# Altium schematic sheet Э3("All Documents",Physical)
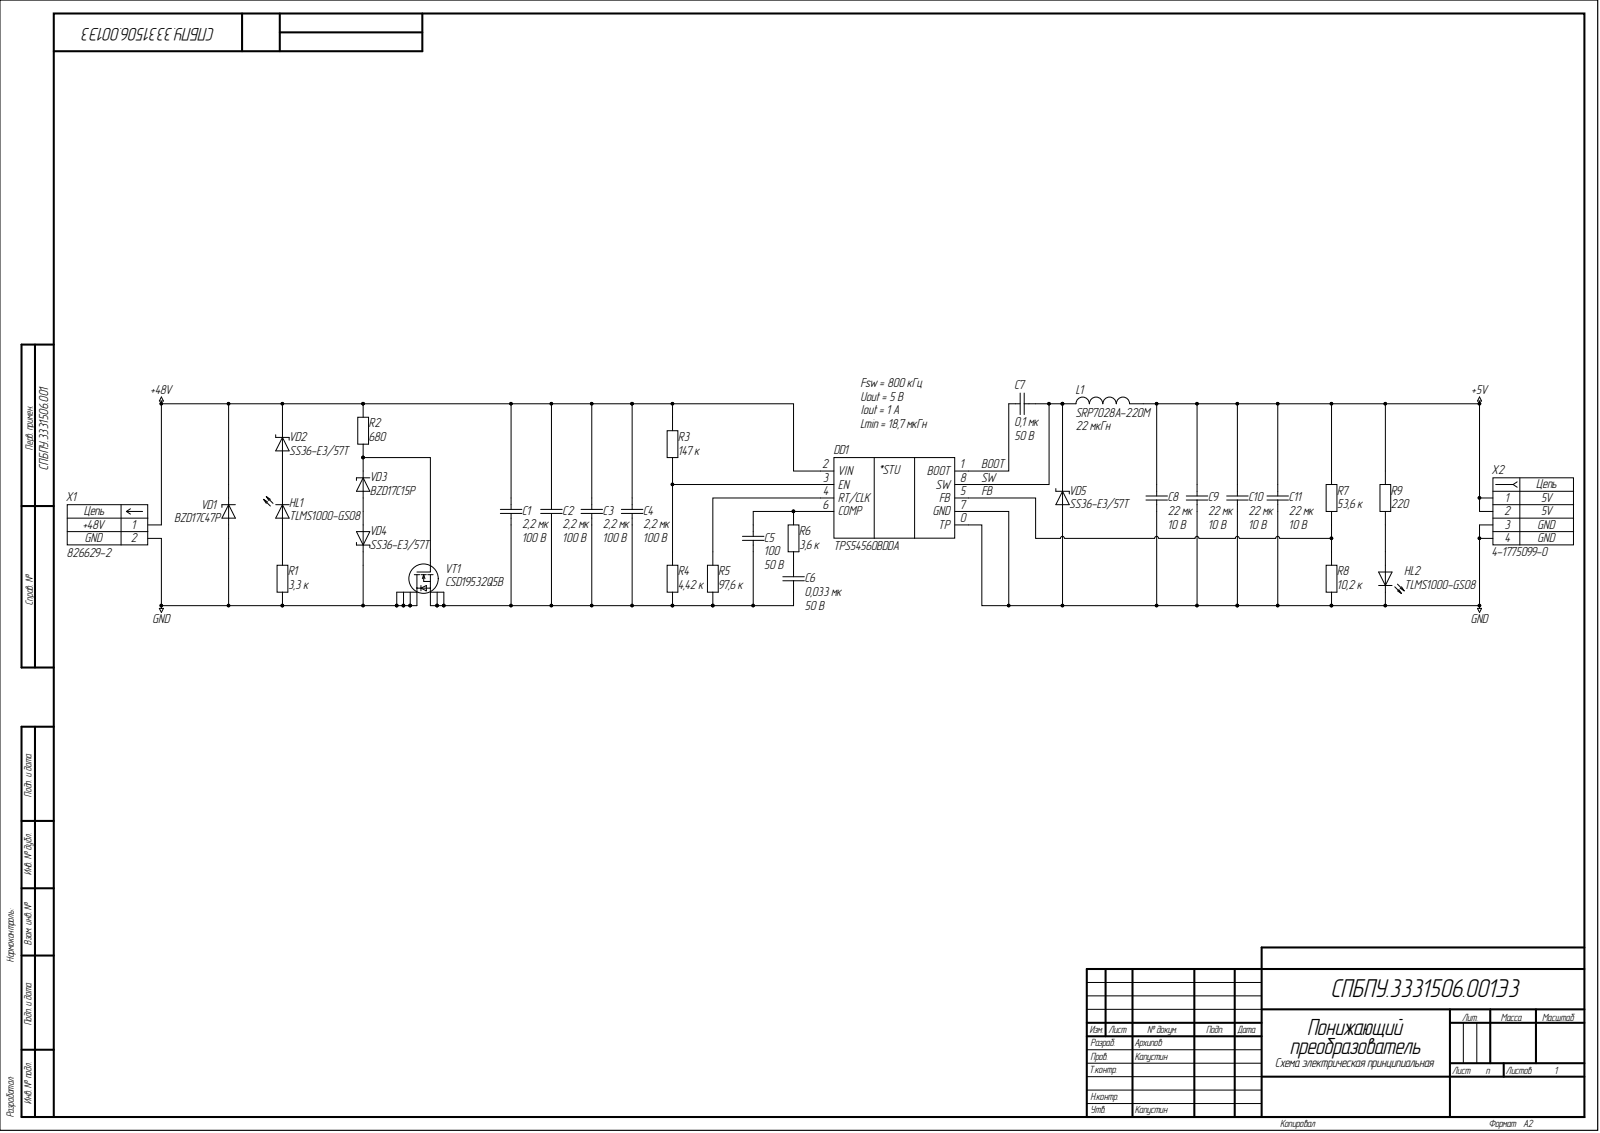
[diagram p0: Э3("All Documents",Physical)]

## Parts
C1  2,2 мк  [C1206]
C2  2,2 мк  Номинальное напряжение: 100 В  [C1206]
C3  2,2 мк  Номинальное напряжение: 100 В  [C1206]
C4  2,2 мк  Номинальное напряжение: 100 В  [C1206]
C5  100  [C0603]
C6  0,033 мк  Номинальное напряжение: 50 В  [C1206]
C7  0,1 мк  Номинальное напряжение: 50 В  [C0603]
C8  22 мк  [C0603]
C9  22 мк  Номинальное напряжение: 10 В  [C0603]
C10  22 мк  Номинальное напряжение: 10 В  [C0603]
C11  22 мк  Номинальное напряжение: 10 В  [C0603]
DD1  TPS54560BDDA  [HSOIC-8]
HL1  TLMS1000-GS08  [HL0603]
HL2  TLMS1000-GS08  [HL0603]
L1  SRP7028A-220M  Номинал: 22 мкГн  [SRP-2-670X660X280]
R1  3,3 к  [R0603]
R2  680  [R0603]
R3  147 к  [R0603]
R4  4,42 к  [R0603]
R5  97,6 к  [R0603]
R6  3,6 к  [R0603]
R7  53,6 к  [R0603]
R8  10,2 к  [R0603]
R9  220  [R0603]
VD1  BZD17C47P  [DO-219AB]
VD2  SS36-E3/57T  [DO-214AB]
VD3  BZD17C15P  [DO-219AB]
VD4  SS36-E3/57T  [DO-214AB]
VD5  SS36-E3/57T  [DO-214AB]
VT1  CSD19532Q5B  [SON-8]
X1  826629-2  Contact_2: GND  Contact_1: +48V  [TE_826629-2]
X2  4-1775099-0  Contact_4: GND  Contact_2: 5V  [4-1775099-0]

## Nets
+5V: C8.1 C9.1 C10.1 C11.1 L1.2 R7.2 R9.2 X2.1 X2.2
+48V: C1.1 C2.1 C3.1 C4.1 DD1.2(VIN) R2.2 R3.2 VD1.1 VD2.1 X1.1
GND: C8.2 C9.2 C10.2 C11.2 DD1.0(TP) DD1.7(GND) HL2.1 R1.1 R8.1 VD1.2 VD4.1 VD5.2 VT1.D X1.2 X2.3 X2.4
BOOT: C7.1 DD1.1(BOOT)
FB: DD1.5(FB) R7.1 R8.2
SW: C7.2 DD1.8(SW) L1.1 VD5.1
NetC1_2: C1.2 C2.2 C3.2 C4.2 C5.2 C6.2 R4.1 R5.1 VT1.S
NetC5_1: C5.1 DD1.6(COMP) R6.2
NetC6_1: C6.1 R6.1
NetDD1_3: DD1.3(EN) R3.1 R4.2
NetDD1_4: DD1.4(RT/CLK) R5.2
NetHL1_1: HL1.1 VD2.2
NetHL1_2: HL1.2 R1.2
NetHL2_2: HL2.2 R9.1
NetR2_1: R2.1 VD3.1 VT1.G
NetVD3_2: VD3.2 VD4.2

## Library data of the parts
C1 = c0027: =Описание; Фамилия: Ларионов; Рабочие температуры: от -55 °С до +125 °С; Производитель: YAGEO Corp.; Отклонение: ±10 %; Описание: Конденсатор чип 1206 2,2 мкФ 100 В ±10 %; Номинальное напряжение: 100 В; Наименование: CC1206KKX7R0BB225; Корпус: 1206; Database Table Name: Конденсаторы$; Номинал: 2,2 мк; Напряжение: 100 В; ID: c0027; HelpURL: https://www.yageo.com/upload/media/product/productsearch/datasheet/mlcc/UPY-NP0X7R_MV_100-to-630V_22.pdf; Description: Конденсатор чип 1206 2,2 мкФ 100 В ±10 %; Component Kind: Standard; Library Name: Database_Students.DbLib
C2-C4 = c0027: =Описание; Фамилия: Ларионов; Рабочие температуры: от -55 °С до +125 °С; Производитель: YAGEO Corp.; Отклонение: ±10 %; Описание: Конденсатор чип 1206 2,2 мкФ 100 В ±10 %; Наименование: CC1206KKX7R0BB225; Корпус: 1206; Database Table Name: Конденсаторы$; Номинал: 2,2 мк; Напряжение: 100 В; ID: c0027; HelpURL: https://www.yageo.com/upload/media/product/productsearch/datasheet/mlcc/UPY-NP0X7R_MV_100-to-630V_22.pdf; Description: Конденсатор чип 1206 2,2 мкФ 100 В ±10 %; Component Kind: Standard; Library Name: Database_Students.DbLib
C5 = c0044: =Описание; Фамилия: Архипов; Рабочие температуры: от -55 °С до +125 °С; Производитель: Murata Manufacturing Co., Ltd.; Отклонение: ±5 %; Описание: Конденсатор чип 0603 100 пФ 50 В ±5 %; Номинальное напряжение: 50 В; Наименование: GRM1885C1H101JA01D; Корпус: 0603; Database Table Name: Конденсаторы$; Номинал: 100; Напряжение: 50 В; ID: c0044; HelpURL: https://www.murata.com/en-eu/products/productdetail?partno=GRM1885C1H101JA01%23; Description: Конденсатор чип 0603 100 пФ 50 В ±5 %; Component Kind: Standard; Library Name: Database_Students.DbLib
C6 = c0043: =Описание; Фамилия: Архипов; Рабочие температуры: от -55 °С до +125 °С; Производитель: Murata Manufacturing Co., Ltd.; Отклонение: ±5 %; Описание: Конденсатор чип 1206 0,033 мкФ 50 В ±5 %; Наименование: GRM3195C1H333JA01; Корпус: 1206; Database Table Name: Конденсаторы$; Номинал: 0,033 мк; Напряжение: 50 В; ID: c0043; HelpURL: https://www.murata.com/en-us/products/productdetail?partno=GRM3195C1H333JA01%23; Description: Конденсатор чип 1206 0,033 мкФ 50 В ±5 %; Component Kind: Standard; Library Name: Database_Students.DbLib
C7 = c0018: =Описание; Фамилия: Ларионов; Рабочие температуры: от -55 °С до +125 °С; Производитель: YAGEO Corp.; Отклонение: ±5 %; Описание: Конденсатор чип 0603 0,1 мкФ 50 В ±5 %; Наименование: CC0603JRX7R9BB104; Корпус: 0603; Database Table Name: Конденсаторы$; Номинал: 0,1 мк; Напряжение: 50 В; ID: c0018; HelpURL: https://www.yageo.com/upload/media/product/products/datasheet/mlcc/UPY-GPHC_X7R_6.3V-to-50V_20.pdf; Description: Конденсатор чип 0603 0,1 мкФ 50 В ±5 %; Component Kind: Standard; Library Name: Database_Students.DbLib
C8 = c0026: =Описание; Фамилия: Чернов; Рабочие температуры: от -55 °С до +85 °С; Производитель: YAGEO Corp.; Отклонение: ±20 %; Описание: Конденсатор чип 0603 22 мкФ 10 В ±20 %; Номинальное напряжение: 10 В; Наименование: CC0603MPX5R6BB226; Корпус: 0603; Database Table Name: Конденсаторы$; Номинал: 22 мк; Напряжение: 10 В; ID: c0026; HelpURL: https://www.yageo.com/upload/media/product/productsearch/datasheet/mlcc/UPY-GPHC_X5R_4V-to-50V_26.pdf; Description: Конденсатор чип 0603 22 мкФ 10 В ±20 %; Component Kind: Standard; Library Name: Database_Students.DbLib
C9-C11 = c0026: =Описание; Фамилия: Чернов; Рабочие температуры: от -55 °С до +85 °С; Производитель: YAGEO Corp.; Отклонение: ±20 %; Описание: Конденсатор чип 0603 22 мкФ 10 В ±20 %; Наименование: CC0603MPX5R6BB226; Корпус: 0603; Database Table Name: Конденсаторы$; Номинал: 22 мк; Напряжение: 10 В; ID: c0026; HelpURL: https://www.yageo.com/upload/media/product/productsearch/datasheet/mlcc/UPY-GPHC_X5R_4V-to-50V_26.pdf; Description: Конденсатор чип 0603 22 мкФ 10 В ±20 %; Component Kind: Standard; Library Name: Database_Students.DbLib
DD1 = dd0007: =Описание; Частота переключения: от 100 кГц до 2,5 МГц; Фамилия: Архипов; Рабочие температуры: от -40 °С до +150 °С; Производитель: Texas Instruments; Описание: Понижающий преобразователь напряжения 60 В и 5 А; Коммутируемый ток: от 0 А до 5 А; Выходное напряжение: от 1 В до 58,8 В; Входное напряжение: от 4,5 В до 60 В; Database Table Name: Преобразователи напряжения$; Наименование: TPS54560BDDA; Корпус: HSOIC-8; ID: dd0007; HelpURL: https://www.ti.com/product/TPS54560B; Description: Понижающий преобразователь напряжения 60 В и 5 А; Component Kind: Standard; Library Name: Database_Students.DbLib
HL1 HL2 = hl0001: =Описание; Цвет: Red; Фамилия: Ларионов; Рассеиваемая мощность: 40 мВт; Рабочие температуры: от -40 °С до +100 °С; Производитель: Vishay Intertechnology, Inc.; Описание: Светодиод чип 0603 красный; Номинальный прямой ток: 15 мА; Номинальное падение напряжения: 1,8 В; Номинальная сила света: 4 мкд; Номинальная длина волны: 628 нм; Корпус: 0603; Database Table Name: Светодиоды$; Наименование: TLMS1000-GS08; ID: hl0001; HelpURL: https://www.vishay.com/leds/list/product-83172/; Description: Светодиод чип 0603 красный; Component Kind: Standard; Library Name: Database_Students.DbLib
L1 = l0004: =Описание; Фамилия: Ларионов; Ток насыщения: 3,5 А; Резонансная частота: 10 МГц; Рабочие температуры: от -55 °С до +150 °С; Рабочее напряжение: не указано; Производитель: Bourns, Inc.; Отклонение: ±20 %; Описание: Катушка индуктивности 22 мкГн ±20 %; Номинальный ток (при нагреве на 20 °С/40 °С): 2 А; Номинальное активное сопротивление: 165 мОм; Максимальное активное сопротивление: 190 мОм; Корпус: 6,7 x 6,6 x 2,8; Database Table Name: Индуктивности$; Наименование: SRP7028A-220M; Индуктивность: 22 мкГн; ID: l0004; HelpURL: https://www.bourns.com/products/magnetic-products/power-inductors-aec-q200-compliant/product/SRP7028A; Description: Катушка индуктивности 22 мкГн ±20 %; Component Kind: Standard; Library Name: Database_Students.DbLib
R1 = r0025: =Описание; Фамилия: Паньков; Рабочие температуры: от -55 °С до +155 °С; Производитель: YAGEO Corp.; Отклонение: ±1 %; Описание: Резистор чип 0603 3,3 кОм ±1 % 0,1 Вт; Номинальный ток: 1 А; Номинальное напряжение: 75 В; Наименование: RC0603FR-073K3L; Корпус: 0603; Database Table Name: Резисторы$; Номинал: 3,3 к; ID: r0025; HelpURL: https://www.yageo.com/upload/media/product/productsearch/datasheet/rchip/PYu-RC_Group_51_RoHS_L_11.pdf; Description: Резистор чип 0603 3,3 кОм ±1 % 0,1 Вт; Component Kind: Standard; Library Name: Database_Students.DbLib
R2 = r0028: =Описание; Фамилия: Архипов; Рабочие температуры: от –55 °С до +155 °С; Производитель: YAGEO Corp.; Отклонение: ±1 %; Описание: Резистор чип 0603 680 Ом ±1 % 0,1 Вт; Номинальный ток: 1 А; Номинальное напряжение: 75 В; Наименование: RC0603JR-07680RL; Корпус: 0603; Database Table Name: Резисторы$; Номинал: 680; ID: r0028; HelpURL: https://www.yageo.com/upload/media/product/productsearch/datasheet/rchip/PYu-RC_Group_51_RoHS_L_11.pdf; Description: Резистор чип 0603 680 Ом ±1 % 0,1 Вт; Component Kind: Standard; Library Name: Database_Students.DbLib
R3 = r0032: =Описание; Фамилия: Жернаков; Рабочие температуры: от -55 °С до +155 °С; Производитель: YAGEO Corp.; Отклонение: ±1 %; Описание: Резистор чип 0603 147 кОм ±1 % 0,1 Вт; Номинальный ток: 1 А; Номинальное напряжение: 75 В; Наименование: RC0603FR-07147KL; Корпус: 0603; Database Table Name: Резисторы$; Номинал: 147 к; ID: r0032; HelpURL: https://www.yageo.com/upload/media/product/productsearch/datasheet/rchip/PYu-RC_Group_51_RoHS_L_11.pdf; Description: Резистор чип 0603 147 кОм ±1 % 0,1 Вт; Component Kind: Standard; Library Name: Database_Students.DbLib
R4 = r0015: =Описание; Фамилия: Жернаков; Рабочие температуры: от -55 °С до +155 °С; Производитель: YAGEO Corp.; Отклонение: ±1 %; Описание: Резистор чип 0603 4,42 кОм ±1 % 0,1 Вт; Номинальный ток: 1 А; Номинальное напряжение: 75 В; Наименование: RC0603FR-074K42L; Корпус: 0603; Database Table Name: Резисторы$; Номинал: 4,42 к; ID: r0015; HelpURL: https://www.yageo.com/upload/media/product/productsearch/datasheet/rchip/PYu-RC_Group_51_RoHS_L_11.pdf; Description: Резистор чип 0603 4,42 кОм ±1 % 0,1 Вт; Component Kind: Standard; Library Name: Database_Students.DbLib
R5 = r0029: =Описание; Фамилия: Водорезов; Рабочие температуры: от -55 °С до +155 °С; Производитель: YAGEO Corp.; Отклонение: ±1 %; Описание: Резистор чип 0603 97,6 кОм ±1 % 0,1 Вт; Номинальный ток: 1 А; Номинальное напряжение: 75 В; Наименование: RC0603FR-0797K6L; Корпус: 0603; Database Table Name: Резисторы$; Номинал: 97,6 к; ID: r0029; HelpURL: https://www.yageo.com/upload/media/product/productsearch/datasheet/rchip/PYu-RC_Group_51_RoHS_L_11.pdf; Description: Резистор чип 0603 97,6 кОм ±1 % 0,1 Вт; Component Kind: Standard; Library Name: Database_Students.DbLib
R6 = r0026: =Описание; Фамилия: Паньков; Рабочие температуры: от -55 °С до +155 °С; Производитель: YAGEO Corp.; Отклонение: ±1 %; Описание: Резистор чип 0603 3,6 кОм ±1 % 0,1 Вт; Номинальный ток: 1 А; Номинальное напряжение: 75 В; Наименование: RC0603FR-073K6L; Корпус: 0603; Database Table Name: Резисторы$; Номинал: 3,6 к; ID: r0026; HelpURL: https://www.yageo.com/upload/media/product/productsearch/datasheet/rchip/PYu-RC_Group_51_RoHS_L_11.pdf; Description: Резистор чип 0603 3,6 кОм ±1 % 0,1 Вт; Component Kind: Standard; Library Name: Database_Students.DbLib
R7 = r0017: =Описание; Фамилия: Жернаков; Рабочие температуры: от -55 °С до +155 °С; Производитель: YAGEO Corp.; Отклонение: ±0,1 %; Описание: Резистор чип 0603 53,6 кОм ±0,1 % 0,1 Вт; Номинальный ток: 1 А; Номинальное напряжение: 75 В; Наименование: RC0603BR-0753K6L; Корпус: 0603; Database Table Name: Резисторы$; Номинал: 53,6 к; ID: r0017; HelpURL: https://www.yageo.com/upload/media/product/productsearch/datasheet/rchip/PYu-RC_Group_51_RoHS_L_11.pdf; Description: Резистор чип 0603 53,6 кОм ±0,1 % 0,1 Вт; Component Kind: Standard; Library Name: Database_Students.DbLib
R8 = r0014: =Описание; Фамилия: Жернаков; Рабочие температуры: от -55 °С до +155 °С; Производитель: YAGEO Corp.; Отклонение: ±1 %; Описание: Резистор чип 0603 10,2 кОм ±1 % 0,1 Вт; Номинальный ток: 1 А; Номинальное напряжение: 75 В; Наименование: RC0603FR-0710K2L; Корпус: 0603; Database Table Name: Резисторы$; Номинал: 10,2 к; ID: r0014; HelpURL: https://www.yageo.com/upload/media/product/productsearch/datasheet/rchip/PYu-RC_Group_51_RoHS_L_11.pdf; Description: Резистор чип 0603 10,2 кОм ±1 % 0,1 Вт; Component Kind: Standard; Library Name: Database_Students.DbLib
R9 = r0009: =Описание; Фамилия: Ларионов; Рабочие температуры: от -55 °С до +155 °С; Производитель: YAGEO Corp.; Отклонение: ±1 %; Описание: Резистор чип 0603 220 Ом ±1 % 0,1 Вт; Номинальный ток: 1 А; Номинальное напряжение: 75 В; Наименование: RC0603FR-07220RL; Корпус: 0603; Database Table Name: Резисторы$; Номинал: 220; ID: r0009; HelpURL: https://www.yageo.com/upload/media/product/productsearch/datasheet/rchip/PYu-RC_Group_51_RoHS_L_11.pdf; Description: Резистор чип 0603 220 Ом ±1 % 0,1 Вт; Component Kind: Standard; Library Name: Database_Students.DbLib
VD1 = vd0028: =Описание; Фамилия: Паньков; Разброс напряжения стабилизации: 44,0...50,0 В; Рабочие температуры: от –55 °С до +150 °С; Производитель: Vishay Intertechnology, Inc.; Описание: Стабилитрон 47 В; Номинальный ток стабилизации: 10 мА; Номинальное напряжение стабилизации: 47 В; Database Table Name: Стабилитроны$; Наименование: BZD17C47P; Корпус: DO-219AB; ID: vd0028; HelpURL: https://www.vishay.com/product?docid=85161; Description: Стабилитрон 47 В; Component Kind: Standard; Library Name: Database_Students.DbLib
VD2 VD4 VD5 = vd0003: =Описание; Фамилия: Жернаков; Рабочие температуры: от -55 °С до +150 °С; Производитель: Vishay Intertechnology, Inc.; Пиковое прямое напряжение: 0,75 В; Описание: Диод Шоттки 60 В 3 А; Макс допустимый средний прямой ток: 3 А; Импульсное обратное напряжение: 60 В; Database Table Name: Диоды$; Наименование: SS36-E3/57T; Корпус: DO-214AB; ID: vd0003; HelpURL: https://www.vishay.com/product?docid=88751; Description: Диод Шоттки 60 В 3 А; Component Kind: Standard; Library Name: Database_Students.DbLib
VD3 = vd0016: =Описание; Фамилия: Жернаков; Разброс напряжения стабилизации: 13,8...15,6 В; Рабочие температуры: от –55 °С до +150 °С; Производитель: Vishay Intertechnology, Inc.; Описание: Стабилитрон 15 В; Номинальный ток стабилизации: 50 мА; Номинальное напряжение стабилизации: 15 В; Database Table Name: Стабилитроны$; Наименование: BZD17C15P; Корпус: DO-219AB; ID: vd0016; HelpURL: https://www.vishay.com/product?docid=85161; Description: Стабилитрон 15 В; Component Kind: Standard; Library Name: Database_Students.DbLib
VT1 = vt0001: =Описание; Фамилия: Жернаков; Ток стока: 17 А; Сопротивление сток-исток: 0,0057 Ом; Рабочие температуры: от -55 °С до +150 °С; Производитель: Texas Instruments; Описание: Транзистор полевой N-канальный 100 В 17 А; Напряжение сток-исток: 100 В; Напряжение затвор-исток: ±20 В; Database Table Name: Транзисторы$; Наименование: CSD19532Q5B; Корпус: SON-8; ID: vt0001; HelpURL: https://www.ti.com/product/CSD19532Q5B?keyMatch=CSD19532Q5B&tisearch=Search-EN-everything&usecase=OPN; Description: Транзистор полевой N-канальный 100 В 17 А; Component Kind: Standard; Library Name: Database_Students.DbLib
X1 = 826629-2: Product_Type: Connector; Number_of_Positions: 2; MF: TE Connectivity; Centerline_Pitch: 2.54 mm[.1 in]; TE_purchase_URL: https://www.te.com/usa-en/product-826629-2.html?te_bu=Cor&te_type=disp&te_campaign=seda_glo_cor-seda-global-disp-prtnr-fy19-seda-model-bom-cta_sma-317_1&elqCampaignId=32493; SnapEDA_Link: https://www.snapeda.com/parts/826629-2/TE%20Connectivity%20AMP%20Connectors/view-part/494607/?ref=snap; Price: None; Package: None; MP: 826629-2; Library Name: SnapEDAsch.SchLib; EU_RoHS_Compliance: Compliant; Component Kind: Standard; Availability: Unavailable
X2 = x0004: =Описание; Фамилия: Ларионов; Производитель: TE Connectivity; Описание: ATX Power 4 pin гнездо прямой; Database Table Name: Разъемы$; Наименование: 4-1775099-0; ID: x0004; HelpURL: https://www.te.com/usa-en/product-4-1775099-0.html; Description: ATX Power 4 pin гнездо прямой; Contact_3: GND; Contact_1: 5V; Component Kind: Standard; Library Name: Database_Students.DbLib
